# Altium schematic sheet Zynq Processing System (PS).SchDoc
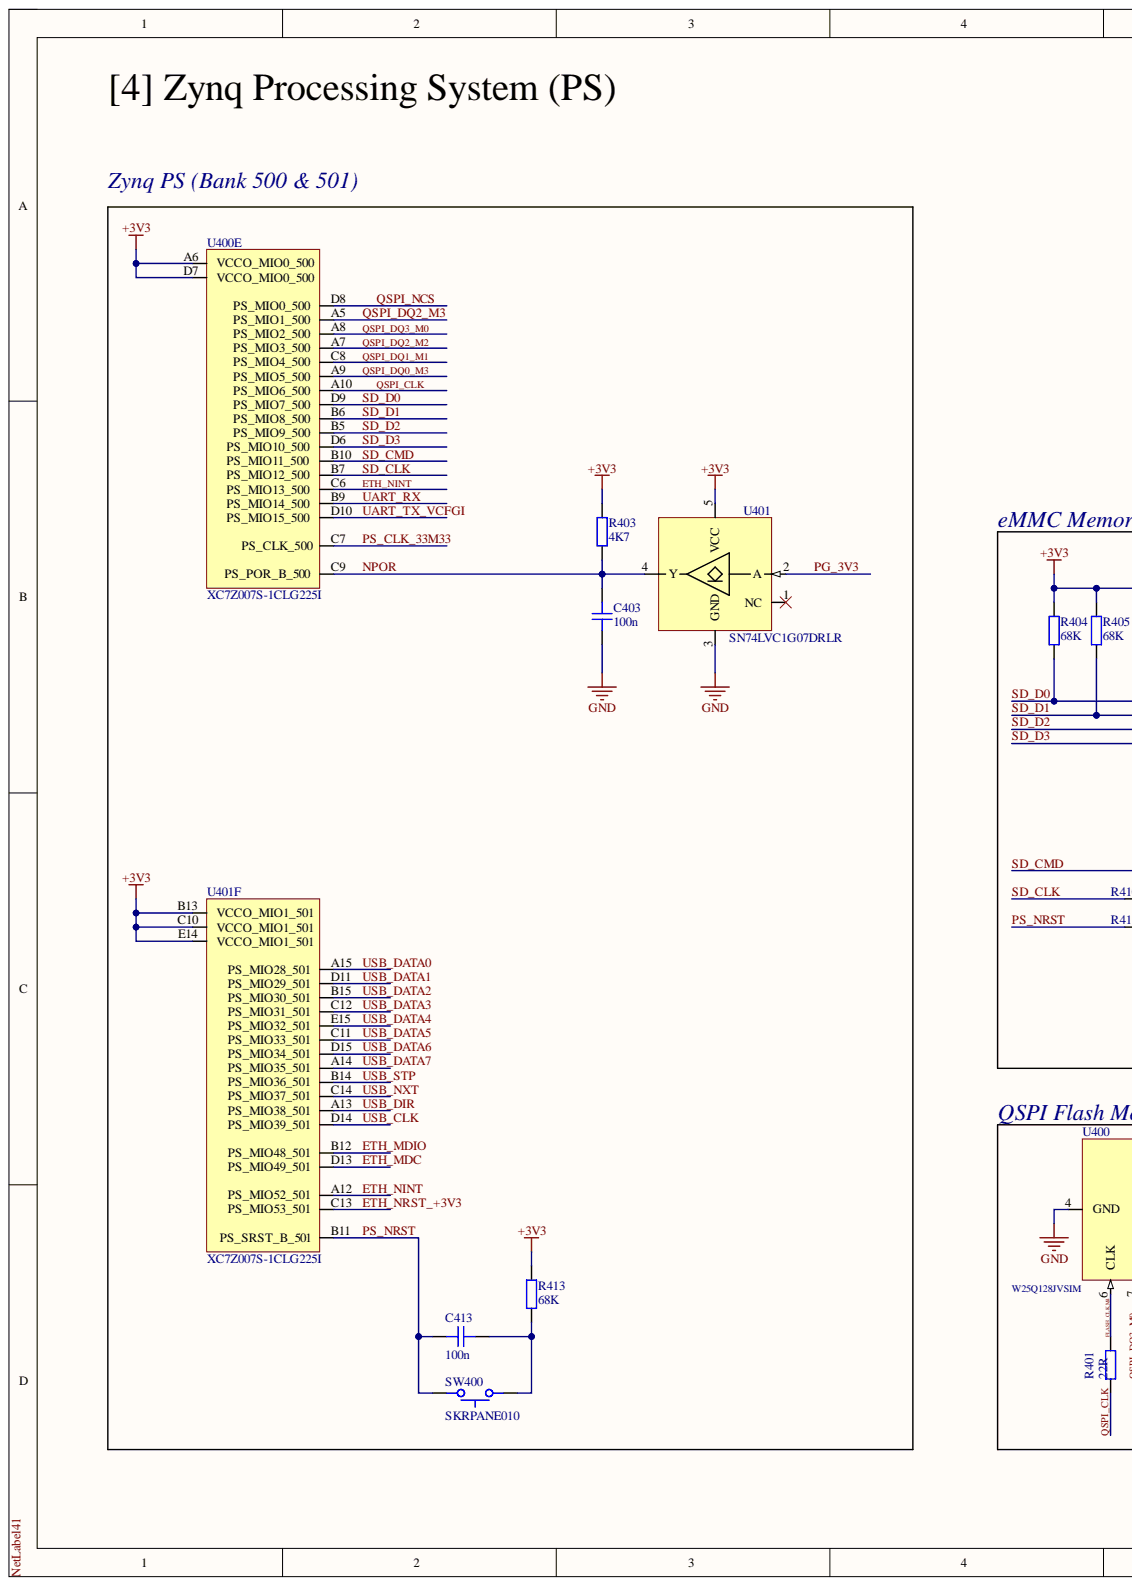
[diagram p0: Zynq Processing System (PS).SchDoc, left]
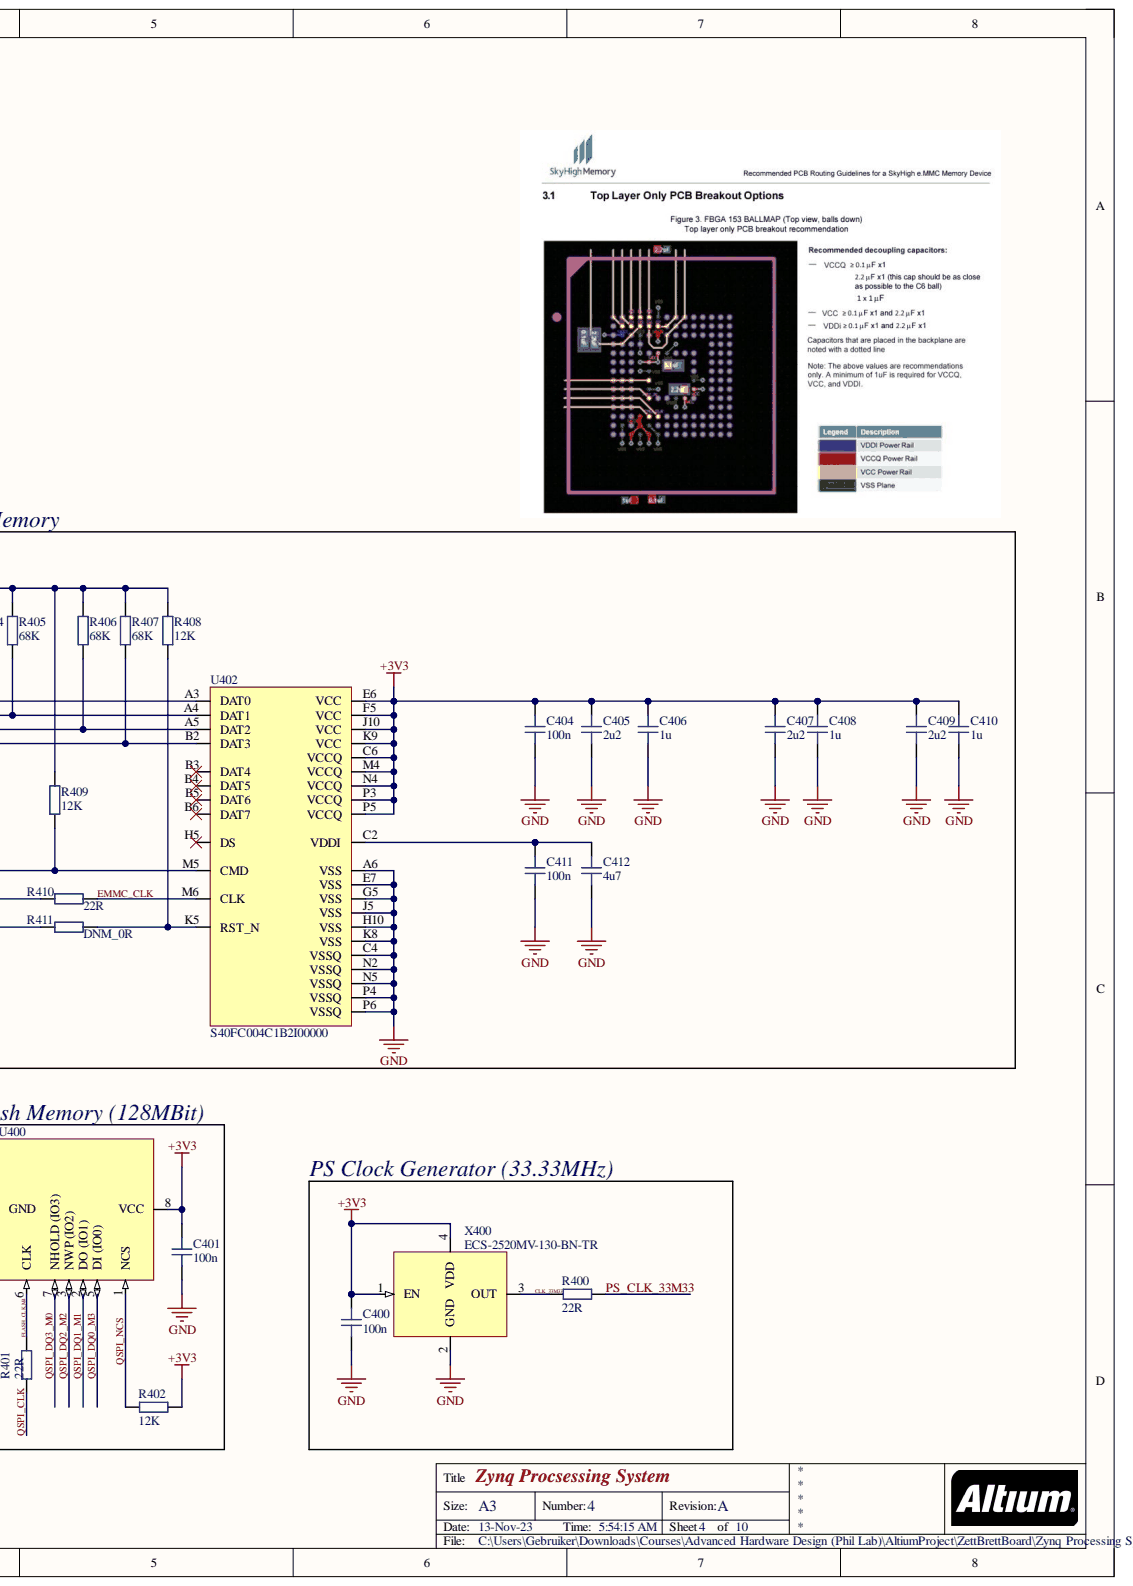
[diagram p1: Zynq Processing System (PS).SchDoc, right]

## Parts
C400  100n  [CAP_1210]
C401  100n  [CAP_1210]
C403  100n  [CAP_1210]
C404  100n  [CAP_1210]
C405  2u2  [CAP_1210]
C406  1u  [CAP_1210]
C407  2u2  [CAP_1210]
C408  1u  [CAP_1210]
C409  2u2  [CAP_1210]
C410  1u  [CAP_1210]
C411  100n  [CAP_1210]
C412  4u7  [CAP_1210]
C413  100n  [CAP_1210]
R400  22R  [RES_0402]
R401  22R  [RES_0402]
R402  12K  [RES_0402]
R403  4K7  [RES_0402]
R404  68K  [RES_0402]
R405  68K  [RES_0402]
R406  68K  [RES_0402]
R407  68K  [RES_0402]
R408  12K  [RES_0402]
R409  12K  [RES_0402]
R410  22R  [RES_0402]
R411  DNM_0R  [RES_0402]
R413  68K  [RES_0402]
SW400  SKRPANE010  [SKRPANE010]
U400  W25Q128JVSIM  [SOIC-8]  (part E)
U401  SN74LVC1G07DRLR  [SOT-5]  (part F)
U402  S40FC004C1B2I00000  [VFBGA153-9.0x7.5x0.8]
X400  ECS-2520MV-130-BN-TR  [XTAL_2520]

## Nets
+3V3: C400.2 C401.2 C404.2 C405.2 C406.2 C407.2 C408.2 C409.2 C410.2 R402.1 R403.1 R404.1 R405.1 R406.1 R407.1 R408.1 R409.1 R413.2 U400.8(VCC) U400.A6 U400.D7 U401.5(VCC) U401.B13 U401.C10 U401.E14 U402.C6(VCCQ) U402.E6(VCC) U402.F5(VCC) U402.J10(VCC) U402.K9(VCC) U402.M4(VCCQ) U402.N4(VCCQ) U402.P3(VCCQ) U402.P5(VCCQ) X400.1(EN) X400.4(VDD)
GND: C400.1 C401.1 C403.1 C404.1 C405.1 C406.1 C407.1 C408.1 C409.1 C410.1 C411.1 C412.1 U400.4(GND) U401.3(GND) U402.A6(VSS) U402.C4(VSSQ) U402.E7(VSS) U402.G5(VSS) U402.H10(VSS) U402.J5(VSS) U402.K8(VSS) U402.N2(VSSQ) U402.N5(VSSQ) U402.P4(VSSQ) U402.P6(VSSQ) X400.2(GND)
CLK_33M33: R400.1 X400.3(OUT)
EMMC_CLK: R410.2 U402.M6(CLK)
ETH_MDC: U401.D13
ETH_MDIO: U401.B12
ETH_NINT: U400.C6 U401.A12
ETH_NRST_+3V3: U401.C13
FLASH_CLK_M4: R401.2 U400.6(CLK)
NPOR: C403.2 R403.2 U400.C9 U401.4(Y)
PG_3V3: U401.2(A)
PS_CLK_33M33: R400.2 U400.C7
PS_NRST: C413.2 R411.1 SW400.34 U401.B11
QSPI_CLK: R401.1 U400.A10
QSPI_DQ0_M3: U400.5(DI_(IO0)) U400.A9
QSPI_DQ1_M1: U400.2(DO_(IO1)) U400.C8
QSPI_DQ2_M2: U400.3(NWP_(IO2)) U400.A7
QSPI_DQ2_M3: U400.A5
QSPI_DQ3_M0: U400.7(NHOLD_(IO3)) U400.A8
QSPI_NCS: R402.2 U400.1(NCS) U400.D8
SD_CLK: R410.1 U400.B7
SD_CMD: R409.2 U400.B10 U402.M5(CMD)
SD_D0: R404.2 U400.D9 U402.A3(DAT0)
SD_D1: R405.2 U400.B6 U402.A4(DAT1)
SD_D2: R406.2 U400.B5 U402.A5(DAT2)
SD_D3: R407.2 U400.D6 U402.B2(DAT3)
UART_RX: U400.B9
UART_TX_VCFGI: U400.D10
USB_CLK: U401.D14
USB_DATA0: U401.A15
USB_DATA1: U401.D11
USB_DATA2: U401.B15
USB_DATA3: U401.C12
USB_DATA4: U401.E15
USB_DATA5: U401.C11
USB_DATA6: U401.D15
USB_DATA7: U401.A14
USB_DIR: U401.A13
USB_NXT: U401.C14
USB_STP: U401.B14
NetC411_2: C411.2 C412.2 U402.C2(VDDI)
NetC413_1: C413.1 R413.1 SW400.12
NetR408_2: R408.2 R411.2 U402.K5(RST_N)
NetLabel41:

Not connected: U401 1(NC); U402 B3(DAT4) B4(DAT5) B5(DAT6) B6(DAT7) H5(DS)

## Library data of the parts
C400 C401 C403-C413 = CAP_1210: Capacitor SMD 1210; Component Kind: Standard; Library Name: Schematic-Lib-PhilsLab.SchLib
R400-R411 R413 = RES_0402: Resistor SMD 0402; Component Kind: Standard; Library Name: Schematic-Lib-PhilsLab.SchLib
SW400 = SKRPANE010: Tactile Switch SMD SPST; Manufacturer: Alps Alpine; Component Kind: Standard; Manufacturer Part Number: SKRPANE010; Library Name: Schematic-Lib-PhilsLab.SchLib
U400 = W25Q128JVSIM: 128M-BIT  SERIAL FLASH MEMORY WITH  DUAL/QUAD SPI & QPI & DTR SOIC-8; Manufacturer: Winbond; Component Kind: Standard; Manufacturer Part Number: W25Q128JVSIM; Library Name: Schematic-Lib-PhilsLab.SchLib
U401 = SN74LVC1G07DRLR: Single Buffer/Driver With Open-Drain Output; Manufacturer: Texas Instruments; Component Kind: Standard; Distributor Link: https://www.mouser.de/ProductDetail/Texas-Instruments/SN74LVC1G07DRLR?qs=pajgIaoyDUjwau6f5T7MtQ%3D%3D; LCSC: C183013; Manufacturer Part Number: SN74LVC1G07DRLR; Library Name: Schematic-Lib-PhilsLab.SchLib
U402 = S40FC004C1B2I00000: eMMC MLC Memory 4GB 3V3; Manufacturer: SkyHigh Memory; Component Kind: Standard; Manufacturer Part Number: S40FC004C1B2I00000; Library Name: Schematic-Lib-PhilsLab.SchLib
X400 = ECS-2520MV-130-BN-TR: Standard Clock Oscillators XO (Standard),13MHz,Standby (Power Down),CMOS, Multi-Volt,1.6 V 3.6 V,+/-50ppm,-40 C 85 C,6mA,Surface Mount,4-SMD, No Lead,(2.50mm x 2.00mm),(0.80mm); Manufacturer: ECS; Component Kind: Standard; Manufacturer Part Number: ECS-2520MV-130-BN-TR; Library Name: Schematic-Lib-PhilsLab.SchLib
